# Altium schematic sheet 03_power_part.SchDoc
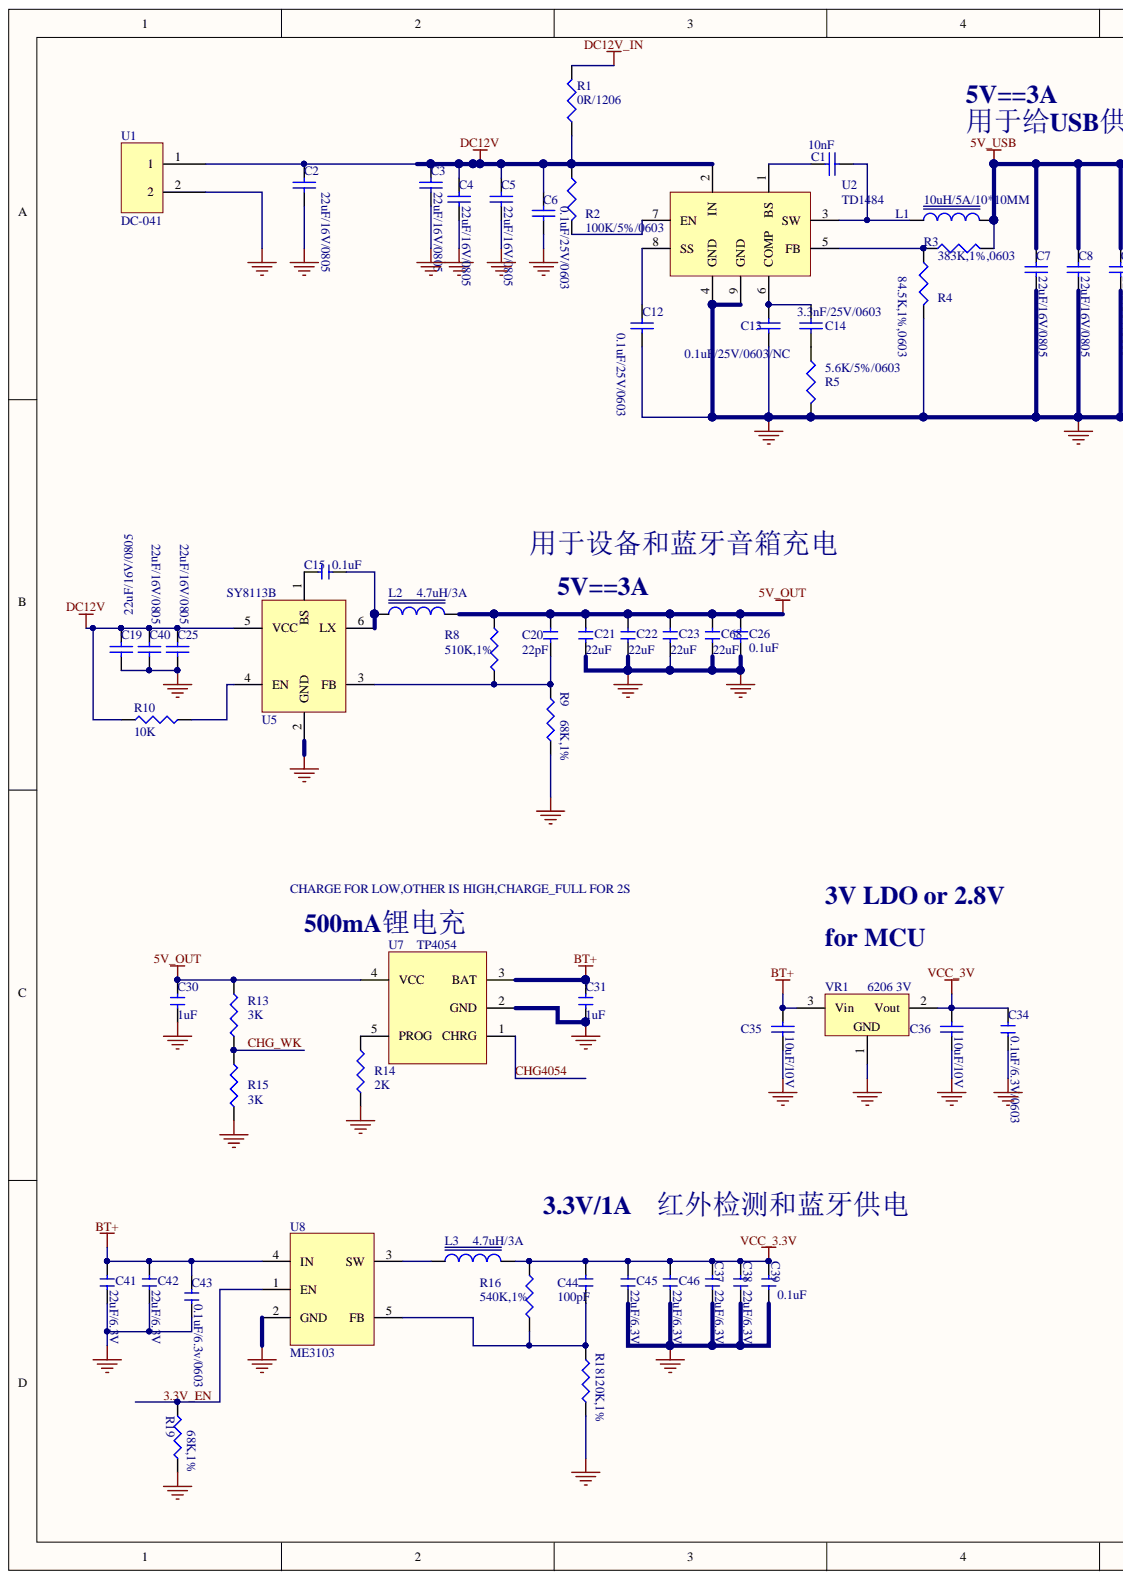
[diagram p0: 03_power_part.SchDoc, left]
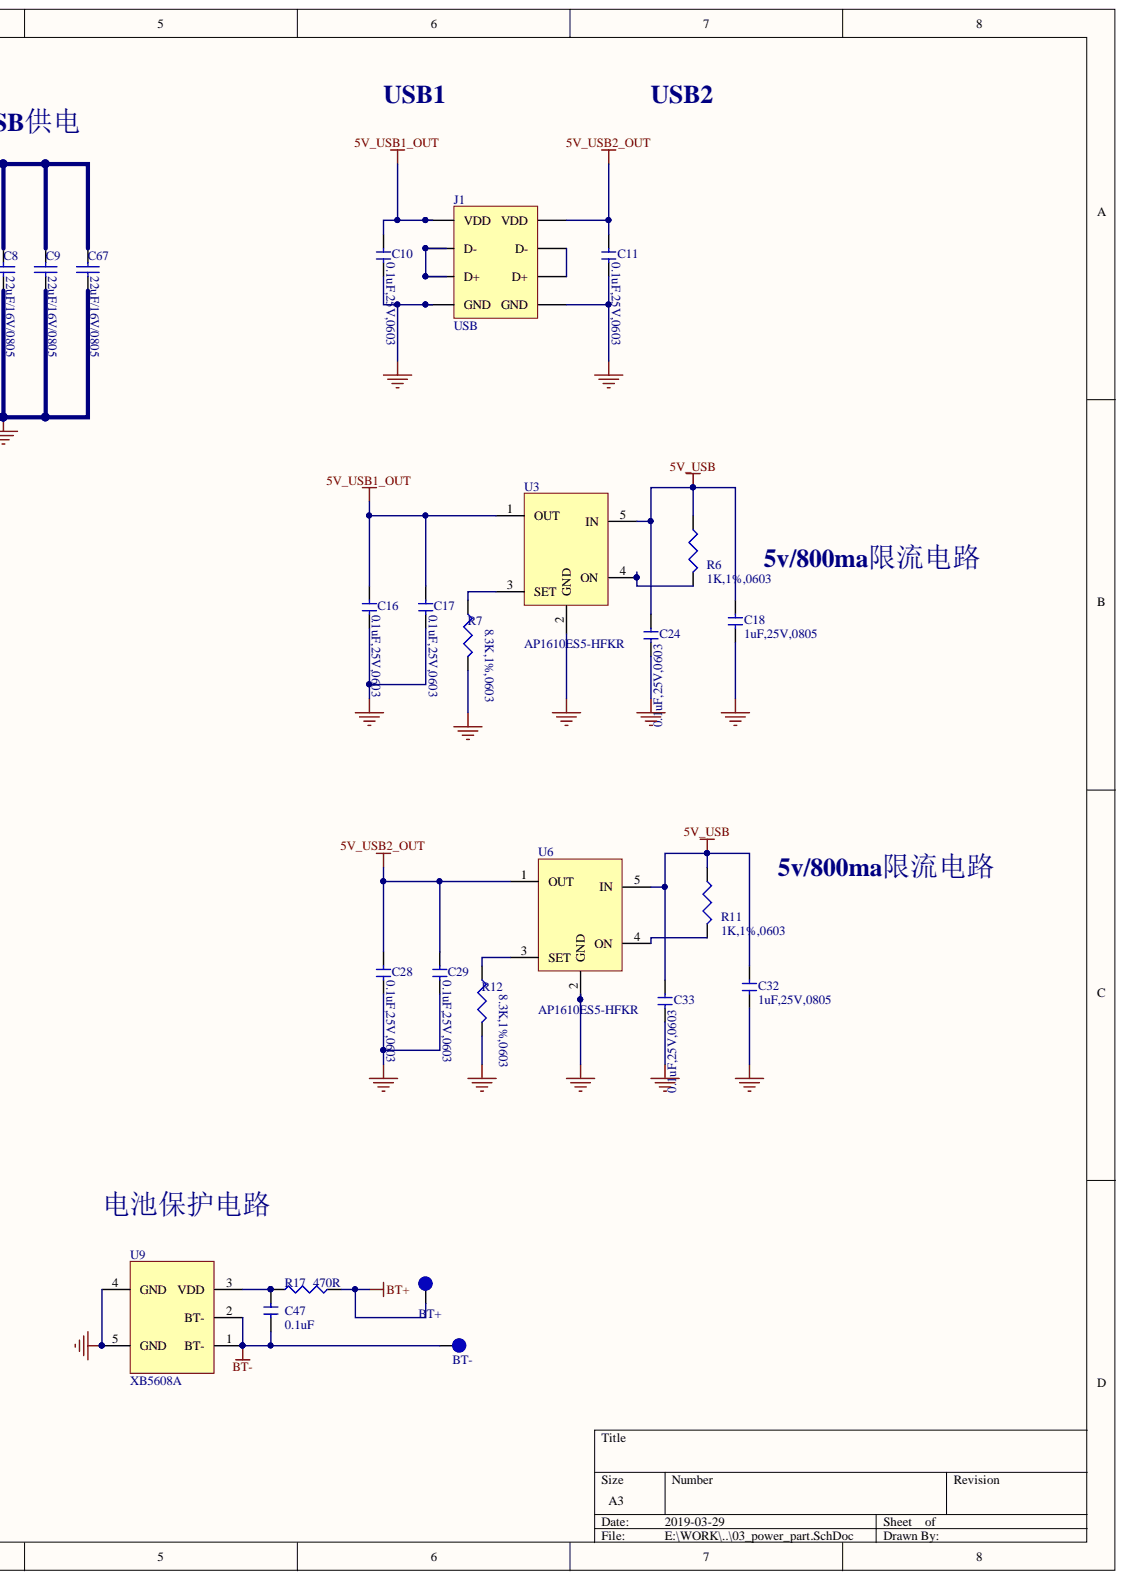
[diagram p1: 03_power_part.SchDoc, right]

## Parts
BT+  TP  [TP_1]  (value not drawn)
BT-  TP  [TP_1]  (value not drawn)
C1  10nF  [0603_C]
C2  22uF/16V/0805  [1206_C]
C3  22uF/16V/0805  [1206_C]
C4  22uF/16V/0805  [1206_C]
C5  22uF/16V/0805  [1206_C]
C6  0.1uF/25V/0603  [0603_C]
C7  22uF/16V/0805  [0805_C]
C8  22uF/16V/0805  [0805_C]
C9  22uF/16V/0805  [0805_C]
C10  0.1uF,25V,0603  [0603_C]
C11  0.1uF,25V,0603  [0603_C]
C12  0.1uF/25V/0603  [0603_C]
C13  value 0.1uF/25V/0603/NC  [0603_C]  (hidden comment: NC)
C14  3.3nF/25V/0603  [0603_C]
C15  0.1uF  [0603_C]
C16  0.1uF,25V,0603  [0603_C]
C17  0.1uF,25V,0603  [0603_C]
C18  1uF,25V,0805  [0805_C]
C19  22uF/16V/0805  [1206_C]
C20  22pF  [0603_C]
C21  22uF  [0805_C]
C22  22uF  [0805_C]
C23  22uF  [0805_C]
C24  0.1uF,25V,0603  [0603_C]
C25  22uF/16V/0805  [1206_C]
C26  0.1uF  [0603_C]
C28  0.1uF,25V,0603  [0603_C]
C29  0.1uF,25V,0603  [0603_C]
C30  1uF  [0805_C]
C31  1uF  [0805_C]
C32  1uF,25V,0805  [0805_C]
C33  0.1uF,25V,0603  [0603_C]
C34  0.1uF/6.3V/0603  [0603_C]
C35  10uF/10V  [0805_C]
C36  10uF/10V  [0805_C]
C37  22uF/6.3V  [0805_C]
C38  22uF/6.3V  [0805_C]
C39  0.1uF  [0603_C]
C40  22uF/16V/0805  [1206_C]
C41  22uF/6.3V  [0805_C]
C42  22uF/6.3V  [0805_C]
C43  0.1uF/6.3v/0603  [0603_C]
C44  100pF  [0603_C]
C45  22uF/6.3V  [0805_C]
C46  22uF/6.3V  [0805_C]
C47  0.1uF  [0603_C]
C67  22uF/16V/0805  [0805_C]
C68  22uF  [0805_C]
J1  USB  [USB_A_DAUL_HT]
L1  10uH/5A/10*10MM  [L_10*10MM]
L2  4.7uH/3A  [CD54]
L3  4.7uH/3A  [CD54]
R1  0R  value 0R/1206  [1206_R]
R2  100K/5%/0603  [0603_R]
R3  383K,1%,0603  [0603_R]
R4  84.5K,1%,0603  [0603_R]
R5  5.6K/5%/0603  [0603_R]
R6  1K,1%,0603  [0603_R]
R7  8.3K,1%,0603  [0603_R]
R8  510K,1%  [0603_R]
R9  68K,1%  [0603_R]
R10  10K  [0603_R]
R11  value 1K,1%,0603  [0603_R]  (hidden comment: 1k)
R12  8.3K,1%,0603  [0603_R]
R13  3K  [0603_R]
R14  2K  [0603_R]
R15  3K  [0603_R]
R16  540K,1%  [0603_R]
R17  470R  [0603_R]
R18  120K,1%  [0603_R]
R19  68K,1%  [0603_R]
U1  value DC-041  [DC-JACK_SMT_DC041]  (hidden comment: IC)
U2  value TD1484  [SOP8_P]  (hidden comment: IC)
U3  AP1610ES5-HFKR  [SOT23-5]
U5  SY8113B  [SOT23-6]
U6  AP1610ES5-HFKR  [SOT23-5]
U7  TP4054  [SOT23-5]
U8  ME3103  [SOT23-5]
U9  XB5608A  [SOT23-5]
VR1  6206 3V  [SOT23]

## Nets
5V_OUT: C20.2 C21.2 C22.2 C23.2 C26.1 C30.1 C68.2 L2.2 R8.2 R13.2 U7.4(VCC)
5V_USB: C7.1 C8.1 C9.1 C18.1 C24.1 C32.1 C33.1 C67.1 L1.1 R3.2 R6.1 R11.1 U3.5(IN) U6.5(IN)
5V_USB1_OUT: C10.1 C16.1 C17.1 J1.1(VDD) U3.1(OUT)
5V_USB2_OUT: C11.1 C28.1 C29.1 J1.5(VDD) U6.1(OUT)
BT+: BT+.1 C31.2 C35.2 C41.2 C42.2 C43.1 R17.1 U7.3(BAT) U8.4(IN) VR1.3
BT-: BT-.1 C47.1 U9.1(BT-) U9.2(BT-)
DC12V: C2.1 C3.1 C4.1 C5.1 C6.1 C19.1 C25.1 C40.1 R1.1 R2.1 R10.1 U1.1 U2.2(IN) U5.5(VCC)
DC12V_IN: R1.2
GND: C2.2 C3.2 C4.2 C5.2 C6.2 C7.2 C8.2 C9.2 C10.2 C11.2 C12.2 C13.2 C16.2 C17.2 C18.2 C19.2 C21.1 C22.1 C23.1 C24.2 C25.2 C26.2 C28.2 C29.2 C30.2 C31.1 C32.2 C33.2 C34.2 C35.1 C36.1 C37.1 C38.1 C39.2 C40.2 C41.1 C42.1 C43.2 C45.1 C46.1 C67.2 C68.1 J1.4(GND) J1.8(GND) R4.2 R5.2 R7.2 R9.1 R12.2 R14.1 R15.1 R18.1 R19.1 U1.2 U2.4(GND) U2.9(GND) U3.2(GND) U5.2(GND) U6.2(GND) U7.2(GND) U8.2(GND) U9.4(GND) U9.5(GND) VR1.1
VCC_3.3V: C37.2 C38.2 C39.1 C44.2 C45.2 C46.2 L3.2 R16.2
VCC_3V: C34.1 C36.2 VR1.2
3.3V_EN: R19.2 U8.1(EN)
CHG4054: U7.1(CHRG)
CHG_WK: R13.1 R15.2
NetC1_1: C1.1 U2.1(BS)
NetC1_2: C1.2 L1.2 U2.3(SW)
NetC12_1: C12.1 U2.8(SS)
NetC13_1: C13.1 C14.1 U2.6(COMP)
NetC14_2: C14.2 R5.1
NetC15_1: C15.1 U5.1(BS)
NetC15_2: C15.2 L2.1 U5.6(LX)
NetC20_1: C20.1 R8.1 R9.2 U5.3(FB)
NetC44_1: C44.1 R16.1 R18.2 U8.5(FB)
NetC47_2: C47.2 R17.2 U9.3(VDD)
NetJ1_2: J1.2(D-) J1.3(D+)
NetJ1_6: J1.6(D-) J1.7(D+)
NetL3_1: L3.1 U8.3(SW)
NetR2_2: R2.2 U2.7(EN)
NetR3_1: R3.1 R4.1 U2.5(FB)
NetR6_2: R6.2 U3.4(ON)
NetR7_1: R7.1 U3.3(SET)
NetR10_2: R10.2 U5.4(EN)
NetR11_2: R11.2 U6.4(ON)
NetR12_1: R12.1 U6.3(SET)
NetR14_2: R14.2 U7.5(PROG)

## Library data of the parts
C1-C9 C12-C14 C19 C25 C40 C67 = Cap Semi: Capacitor (Semiconductor SIM Model); Component Kind: Standard; LatestRevisionDate: 17-Jul-2002; LatestRevisionNote: Re-released for DXP Platform.; PackageReference: C1206; Published: 8-Jun-2000; Publisher: Altium Limited
  C1: Value: 10nF
  C2-C5 C7-C9 C19 C25 C40 C67: Value: 22uF/16V/0805
  C6 C12: Value: 0.1uF/25V/0603
  C14: Value: 3.3nF/25V/0603
C10 C11 C15-C18 C20-C24 C26 C28-C34 C37-C39 C41-C47 C68 = Cap: Component Kind: Standard; Library Name: Celestial.SchLib; Type: Cap
C35 C36 = Cap Semi: Capacitor (Semiconductor SIM Model); Type: Cap; Component Kind: Standard; LatestRevisionDate: 17-Jul-2002; LatestRevisionNote: Re-released for DXP Platform.; PackageReference: C1206; Published: 8-Jun-2000; Publisher: Altium Limited
J1 = USB_A_DAUL: Component Kind: Standard; Value: USB; Library Name: Jackson SCH.LIB
L1-L3 = Inductor: Component Kind: Standard
  L1: Value: 10uH/5A/10*10MM; Library Name: Jackson SCH.LIB
  L2 L3: Library Name: Celestial.SchLib; Type: Inductor
R1 = Res3: Component Kind: Standard; Description: 0R; LatestRevisionDate: 17-Jul-2002; LatestRevisionNote: Re-released for DXP Platform.; Published: 8-Jun-2000; Publisher: Altium Limited
R2-R7 R11 R12 = Res3: Resistor; Component Kind: Standard; LatestRevisionDate: 17-Jul-2002; LatestRevisionNote: Re-released for DXP Platform.; Published: 8-Jun-2000; Publisher: Altium Limited
  R2: Value: 100K/5%/0603
  R3: Value: 383K,1%,0603
  R4: Value: 84.5K,1%,0603
  R5: Value: 5.6K/5%/0603
  R6: Value: 1K,1%,0603
  R7 R12: Value: 8.3K,1%,0603
R8-R10 R13-R19 = Res: Component Kind: Standard; Library Name: Celestial.SchLib; Type: Res
U1 = HEADE1X2: Component Kind: Standard; Library Name: Jackson SCH.LIB
U2 = TD1484_SOP8: Component Kind: Standard; Library Name: Jackson SCH.LIB
U5 = SY8120B: Component Kind: Standard; TYPE: IC; Library Name: Jackson SCH.LIB
U7 = TP4054_SOT25: Component Kind: Standard; Library Name: Celestial.SchLib; Type: IC
U9 = XB5608G: Component Kind: Standard; Library Name: Celestial.SchLib; Type: IC
VR1 = Volt Reg: Voltage Regulator; Code_JEDEC: SMD-220; Component Kind: Standard; LatestRevisionDate: 17-Jul-2002; LatestRevisionNote: Re-released for DXP Platform.; Library Name: Miscellaneous Devices.IntLib; Published: 24-Mar-1999; Publisher: Altium Limited
BT+ BT- = TP: Component Kind: Standard; Library Name: Jackson SCH.LIB
U3 U6 = AP1610ES5-HFKR: Component Kind: Standard; Value: AP1610ES5-HFKR; Library Name: Jackson SCH.LIB
U8 = ME3103: Component Kind: Standard; Value: ME3103; Library Name: Jackson SCH.LIB
